# Altium schematic sheet ESP12E-S.SchDoc
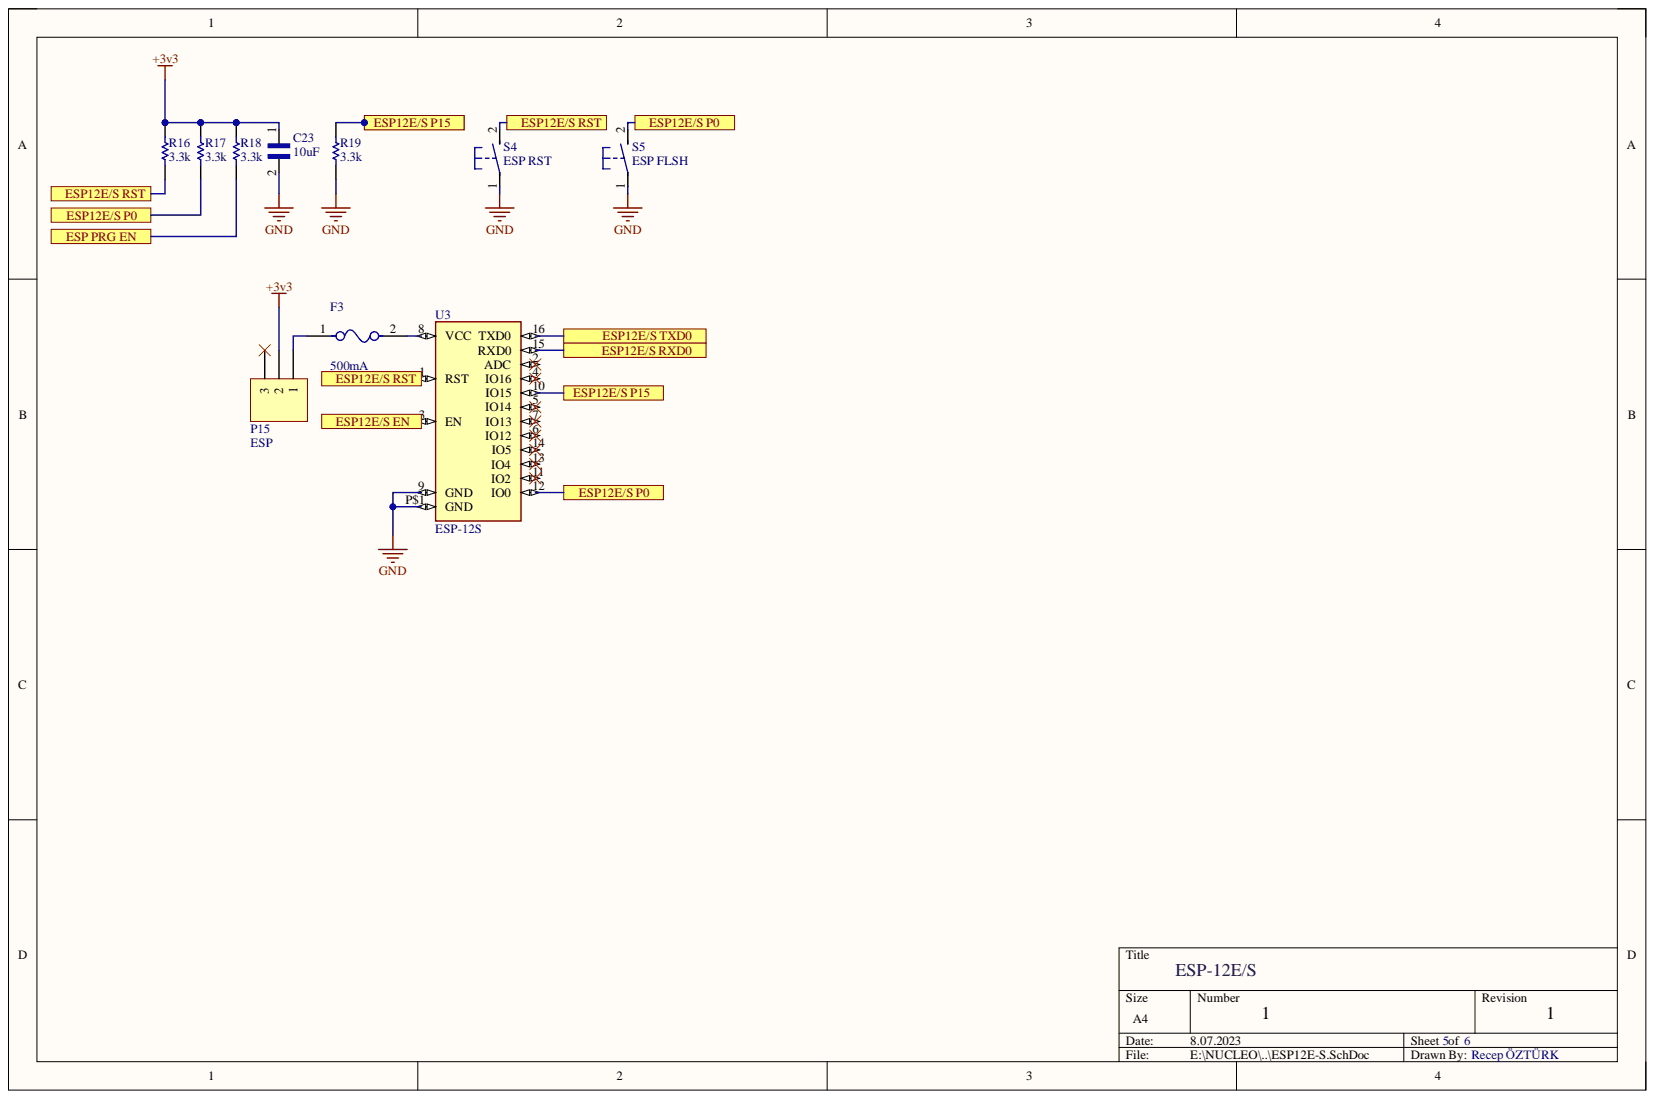
[diagram p0: ESP12E-S.SchDoc]

## Parts
C23  10uF  [CAPC1608X95N]
F3  500mA  [FP-MF-NSMF012-2-MFG]
P15  ESP  [HDR1X3]  (jumper)
R16  value 3.3k  [RESC1608X50N]  (hidden comment: Res1)
R17  value 3.3k  [RESC1608X50N]  (hidden comment: Res1)
R18  value 3.3k  [RESC1608X50N]  (hidden comment: Res1)
R19  value 3.3k  [RESC1608X50N]  (hidden comment: Res1)
S4  ESP RST  [DTS-3]
S5  ESP FLSH  [DTS-3]
U3  ESP-12S  [ESP-12S]

## Ports
ESP12E/S EN, ESP12E/S P0, ESP12E/S P15, ESP12E/S RST, ESP12E/S RXD0, ESP12E/S TXD0, ESP PRG EN

## Nets
+3v3: C23.1 P15.2 R16.2 R17.2 R18.2
GND: C23.2 R19.1 S4.1 S5.1 U3.9(GND) U3.P$1(GND)
ESP12E/S EN: U3.3(EN) ; port ESP12E/S EN
ESP12E/S P0: R17.1 S5.2 U3.12(IO0) ; port ESP12E/S P0
ESP12E/S P15: R19.2 U3.10(IO15) ; port ESP12E/S P15
ESP12E/S RST: R16.1 S4.2 U3.1(RST) ; port ESP12E/S RST
ESP12E/S RXD0: U3.15(RXD0) ; port ESP12E/S RXD0
ESP12E/S TXD0: U3.16(TXD0) ; port ESP12E/S TXD0
ESP PRG EN: R18.1 ; port ESP PRG EN
NetF3_1: F3.1 P15.1
NetF3_2: F3.2 U3.8(VCC)

Not connected: P15 3; U3 2(ADC) 4(IO16) 5(IO14) 6(IO12) 7(IO13) 11(IO2) 13(IO4) 14(IO5)

## Library data of the parts
C23 = CL21A106KAFN3NE: 10uF 10v 0603  LCSC = C19702; MF: {'manufacturer': u'Samsung Electro-Mechanics'}; Availability: Unavailable; Component Kind: Standard; Library Name: SnapEDA_Library.IntLib; MP: CL21A106KAFN3NE; Package: None; Price: None
F3 = CMP-07400-000025-1: PTC RESET FUSE 8V 500mA 1206  Direnc.net; Manufacturer: Bourns Inc.; Manufacturer Part Number: MF-NSMF012-2; Automotive Grade: Grade 3; Current Rating: 10A; Hold Current: 0.12A; Resistance: 1.35-8.5Ω; Trip Current: 0.29A; Trip Time: 0.2s; Value: 1.35-8.5Ω; Voltage Rating: 30V; Ambient Temperature Range High: +85°C; Ambient Temperature Range Low: -40°C; Automotive: Yes; Category: Fuse; Component Kind: Standard; ComponentLink2Description: Datasheet; ComponentLink2URL: https://www.bourns.com/docs/Product-Datasheets/mfnsmf.pdf; Device Class L1: Circuit Protection; Device Class L2: PTC Resettable Fuses; Device Class L3: unset; Digikey Description: PTC RESET FUSE 30V 120MA 1206; Height: 1.1mm; Lead Free: Yes; Library Name: Altium Content Vault; Mouser Description: Resettable Fuses - PPTC 0.12A 30V 1.35ohm; Octopart: https://octopart.com/mf-nsmf012-2-bourns-24569; Package: MF-NSMF012-2; RoHS: TRUE; Temperature: -40°C to +85°C; Temperature Range High: +85°C; Temperature Range Low: -40°C
P15 = Header 3: Header, 3-Pin; LatestRevisionDate: 17-Jul-2002; LatestRevisionNote: Re-released for DXP Platform.; Library Name: Miscellaneous Connectors.IntLib; Signal Integrity: Connector; Publisher: Altium Limited
R16-R19 = Res1: Chip Resistor - Surface Mount 3.3KOhms ±1% 1/10W 0603 RoHS C22978; Component Kind: Standard; LatestRevisionDate: 17-Jul-2002; LatestRevisionNote: Re-released for DXP Platform.; Library Name: Miscellaneous Devices.IntLib; PackageDescription: Axial Device, Thru-Hole; 2 Leads; 0.3 in Pin Spacing; PackageReference: AXIAL-0.3; Published: 8-Jun-2000; Signal Integrity: Res; Simulation: RESISTOR; Publisher: Altium Limited
S4 S5 = DTS-3: MF: {'manufacturer': u'APEM Inc.'}; Availability: Unavailable; Component Kind: Standard; Library Name: SnapEDA_Library.IntLib; MP: DTS-3; Package: None; Price: None
U3 = ESP-12S: ESP12E/S Direnc.net; MF: {'manufacturer': u'RF Solutions'}; Availability: Unavailable; Component Kind: Standard; Library Name: SnapEDA_Library.IntLib; MP: ESP-12S; Package: None; Price: None
